# Altium schematic sheet CSI2-IXORA_Rev01.SchDoc(CSI2-IXORA_Rev01)
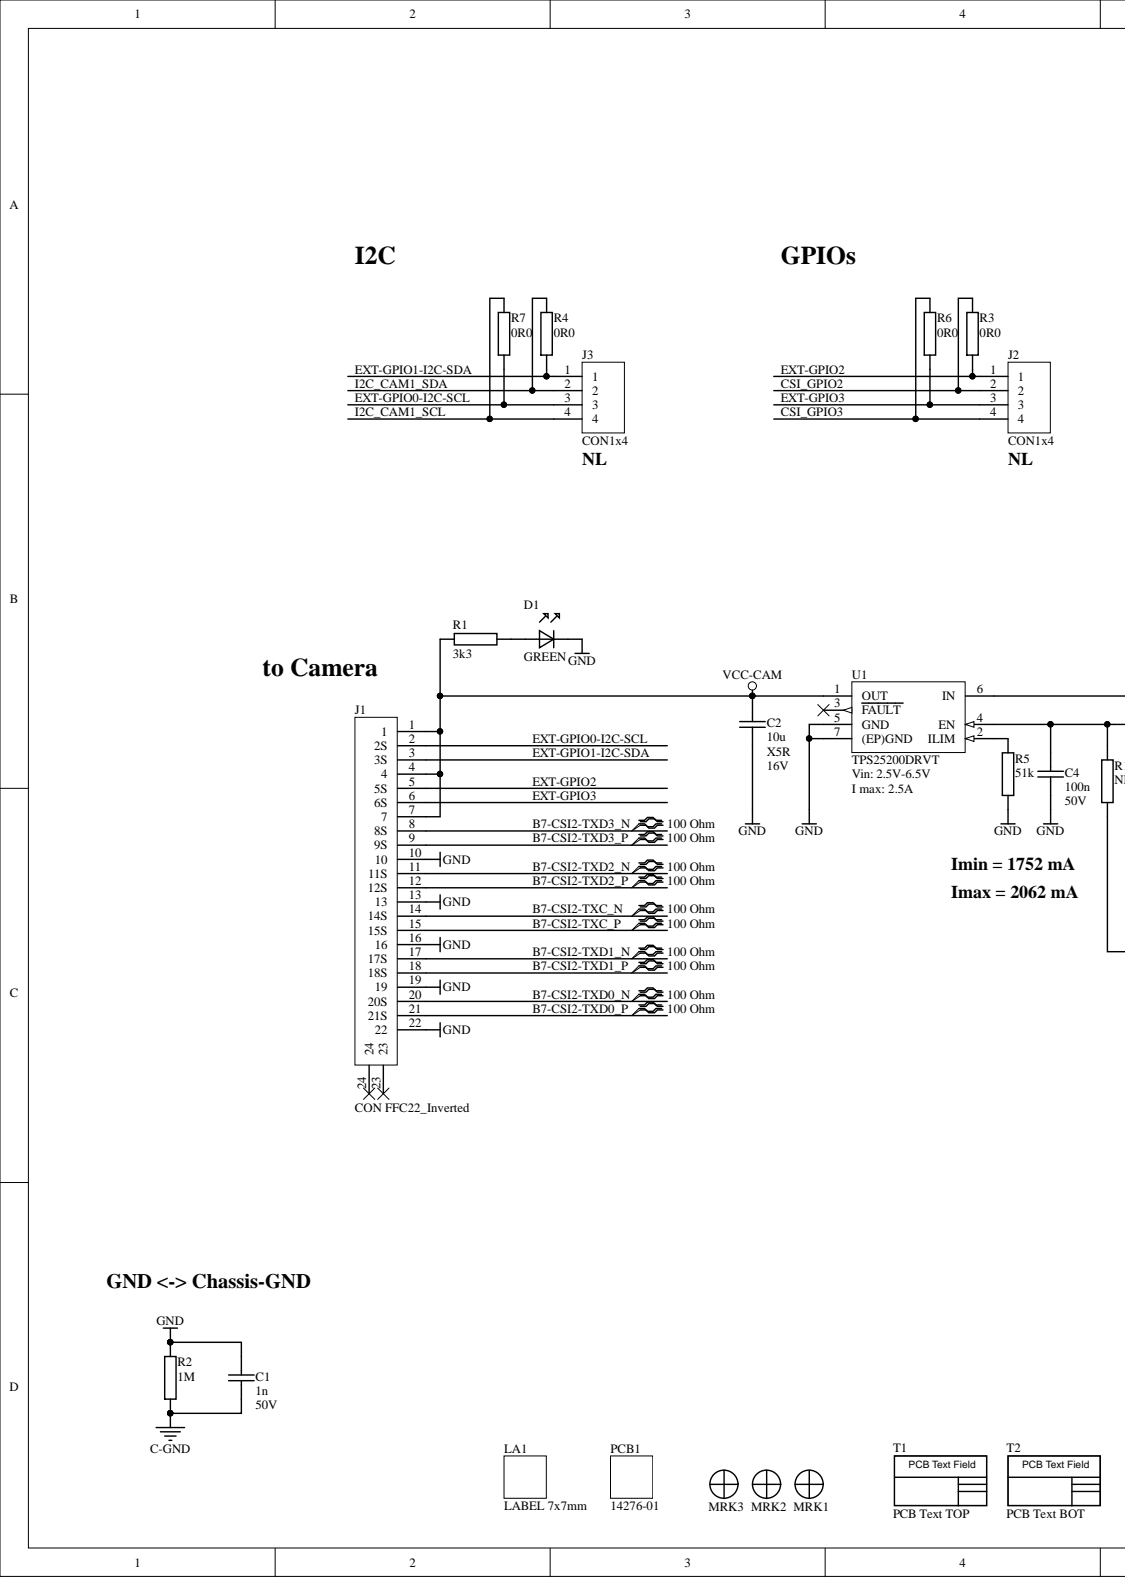
[diagram p0: CSI2-IXORA_Rev01.SchDoc, left]
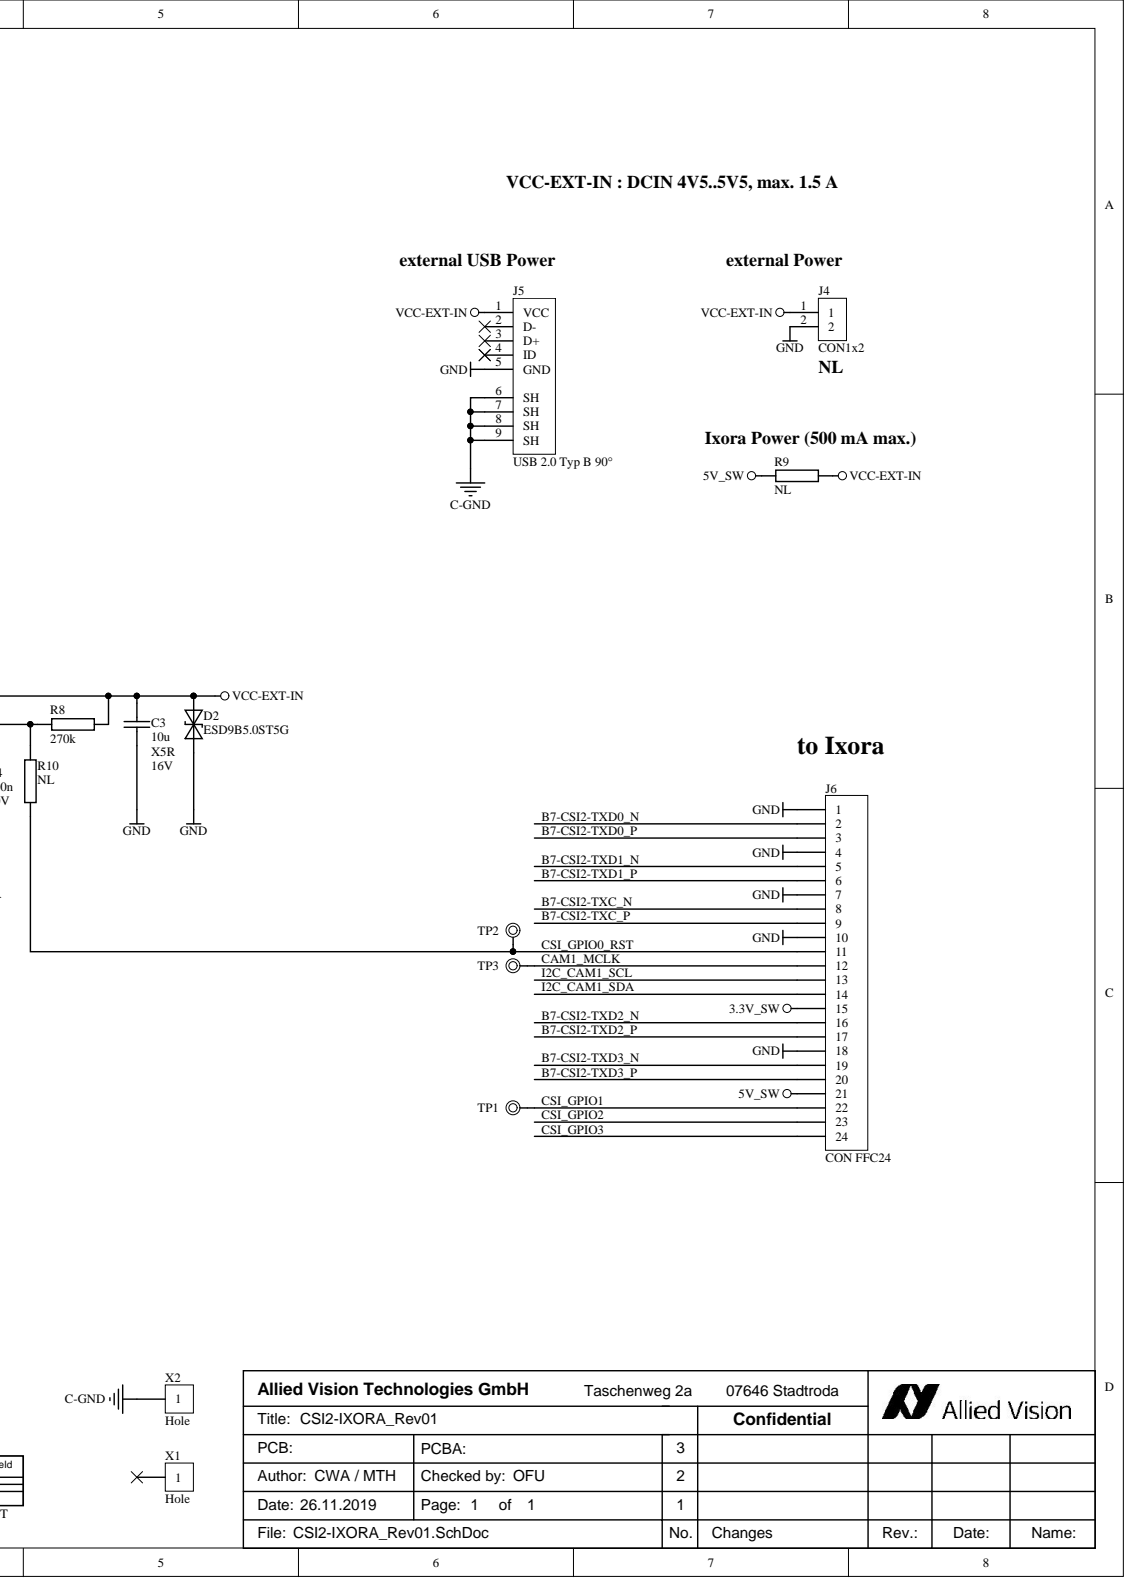
[diagram p1: CSI2-IXORA_Rev01.SchDoc, right]

## Parts
C1  1n  Voltage: 50V  [C-0603_1.0]
C2  10u  Voltage: 16V  Dielectric: X5R  [C-0805_1.4]
C3  10u  Voltage: 16V  Dielectric: X5R  [C-0805_1.4]
C4  100n  Voltage: 50V  [C-0603_1.0]
D1  GREEN  [D-0603-LED]
D2  ESD9B5.0ST5G  [D-SOD923]
J1  CON FFC22_Inverted  [J-FH55-22S-0.5SH - Inverted Pins]
J2  CON1x4  [CON 1x4 RM2.54]
J3  CON1x4  [CON 1x4 RM2.54]
J4  CON1x2  [CON 1x2 RM2.54]
J5  USB 2.0 Typ B 90°  [J-629105150521]
J6  CON FFC24  [J-687124182122]
LA1  LABEL 7x7mm  [PCB LABEL 7x7]
MRK1  Marker Single Layer  [PCB_Marker_Pad_top]  (value not drawn)
MRK2  Marker Single Layer  [PCB_Marker_Pad_top]  (value not drawn)
MRK3  Marker Single Layer  [PCB_Marker_Pad_top]  (value not drawn)
PCB1  14276-01  (mechanical)
R1  3k3  [R-0603_0.6]
R2  1M  [R-0603_0.6]
R3  0R0  [R-0603_0.6]
R4  0R0  [R-0603_0.6]
R5  51k  [R-0603_0.6]
R6  0R0  [R-0603_0.6]
R7  0R0  [R-0603_0.6]
R8  270k  [R-0603_0.6]
R9  NL  [R-0603_0.6]
R10  NL  [R-0603_0.6]
T1  PCB Text TOP  [PCB TEXTFIELD TOP 2]  (no BOM)
T2  PCB Text BOT  [PCB TEXTFIELD BOT 2]  (no BOM)
TP1  TP  [TP_16_10]  (no BOM)
TP2  TP  [TP_16_10]  (no BOM)
TP3  TP  [TP_16_10]  (no BOM)
U1  TPS25200DRVT  Vin: 2.5V-6.5V  I max: 2.5A  [IC-DFN06_2.0x2.0x0.8]
X1  Hole  [HOLE-2.6-5.0-DK]  (no BOM)
X2  Hole  [HOLE-2.6-5.0-DK]  (no BOM)

## Nets
3.3V_SW: J6.15
5V_SW: J6.21 R9.2
C-GND: C1.2 J5.6(SH) J5.7(SH) J5.8(SH) J5.9(SH) R2.1 X2.1
GND: C1.1 C2.2 C3.2 C4.2 D1.K D2.A J1.10 J1.13 J1.16 J1.19 J1.22 J4.2 J5.5(GND) J6.1 J6.4 J6.7 J6.10 J6.18 R2.2 R5.1 U1.5(GND) U1.7((EP)GND)
VCC-CAM: C2.1 J1.1 J1.4 J1.7 R1.1 U1.1(OUT)
VCC-EXT-IN: C3.1 D2.K J4.1 J5.1(VCC) R8.2 R9.1 U1.6(IN)
B7-CSI2-TXC_N: J1.14(14S) J6.8
B7-CSI2-TXC_P: J1.15(15S) J6.9
B7-CSI2-TXD0_N: J1.20(20S) J6.2
B7-CSI2-TXD0_P: J1.21(21S) J6.3
B7-CSI2-TXD1_N: J1.17(17S) J6.5
B7-CSI2-TXD1_P: J1.18(18S) J6.6
B7-CSI2-TXD2_N: J1.11(11S) J6.16
B7-CSI2-TXD2_P: J1.12(12S) J6.17
B7-CSI2-TXD3_N: J1.8(8S) J6.19
B7-CSI2-TXD3_P: J1.9(9S) J6.20
CAM1_MCLK: J6.12 TP3.1
CSI_GPIO0_RST: J6.11 R10.1 TP2.1
CSI_GPIO1: J6.22 TP1.1
CSI_GPIO2: J2.2 J6.23 R3.2
CSI_GPIO3: J2.4 J6.24 R6.2
EXT-GPIO0-I2C-SCL: J1.2(2S) J3.3 R7.1
EXT-GPIO1-I2C-SDA: J1.3(3S) J3.1 R4.1
EXT-GPIO2: J1.5(5S) J2.1 R3.1
EXT-GPIO3: J1.6(6S) J2.3 R6.1
I2C_CAM1_SCL: J3.4 J6.13 R7.2
I2C_CAM1_SDA: J3.2 J6.14 R4.2
NetC4_1: C4.1 R8.1 R10.2 U1.4(EN)
NetD1_A: D1.A R1.2
NetR5_2: R5.2 U1.2(ILIM)

Not connected: J1 23 24; J5 2(D-) 3(D+) 4(ID); U1 3(FAULT); X1 1

## Library data of the parts
C1 = C 1N 50V X7R 0603: Capacitor Ceramic SMD/0603/50V/X7R/10%; Manufacturer Part Number: several; KHK Part Number: K8340038; Tolerance: 10%; Dielectric: X7R; Manufacturer: several; Component Kind: Standard; Library Name: AVT_KHK_Lib.IntLib
C2 C3 = C 10U 16V X5R 0805: Capacitor Ceramic SMD/0805/16V/X5R/10%; Manufacturer Part Number: several; KHK Part Number: K8340151; Tolerance: 10%; Manufacturer: several; Component Kind: Standard; Library Name: AVT_KHK_Lib.IntLib
C4 = C 100N 50V X7R 0603: Capacitor Ceramic SMD/0603/50V/X7R/10%; Manufacturer Part Number: several; KHK Part Number: K8340023; Tolerance: 10%; Dielectric: X7R; Manufacturer: several; Component Kind: Standard; Library Name: AVT_KHK_Lib.IntLib
D1 = D LED 0603 green: Chip LED green 430mcd; Manufacturer Part Number: 150060GS75000; Manufacturer: Würth; KHK Part Number: 8172; Current: 20mA; Component Kind: Standard; Color: green; Library Name: AVT_KHK_Lib.IntLib
D2 = D ESD9B5.0ST5G: DIODE TVS; 400W; 5.8-7.8V; BIDIRECT; Manufacturer: ON Semiconductor; KHK Part Number: 7250; Manufacturer Part Number: ESD9B5.0ST5G; Component Kind: Standard; Library Name: AVT_KHK_Lib.IntLib
J1 = CON FFC22_FH55-22S-0.5SH_Inverted: FFC Connector 0.5mm shielded; Manufacturer: Hirose; KHK Part Number: 10357; Manufacturer Part Number: FH55-22S-0.5SH; Component Kind: Standard; Library Name: AVT_KHK_Lib.IntLib
J2 J3 = CON 1x4 2.54: Stiftleiste; 1x4; 2.54mm; gerade; Manufacturer Part Number: M20-999 0445; Manufacturer: Harwin; KHK Part Number: K7600564; Component Kind: Standard; Library Name: AVT_KHK_Lib.IntLib
J4 = CON 1x2 2.54: Stiftleiste; 1x2; 2.54mm; gerade; Manufacturer Part Number: SL 11 124 2 G; Manufacturer: fischer elektronik; KHK Part Number: K7600582; Component Kind: Standard; Library Name: AVT_KHK_Lib.IntLib
J5 = CON USB 2.0 Typ B 90°: Micro USB 2.0 Typ B 90°; Manufacturer Part Number: 629 105 150 521; Manufacturer: WE; KHK Part Number: 14360; Library Name: Connector.SCHLIB; Component Kind: Standard
J6 = CON FFC24_WE_687124182122: FFC Connector 0.5mm; Manufacturer: Würth; KHK Part Number: 14361; Manufacturer Part Number: 687124182122; Component Kind: Standard; Library Name: AVT_KHK_Lib.IntLib
LA1 = PCB LABEL 7x7: Manufacturer Part Number: several; Manufacturer: several; Description: LABEL 7x7mm; Component Kind: Standard; Library Name: AVT_KHK_Lib.IntLib
MRK1-MRK3 = PCB Marker Pad Single Layer: Position Marker for PCBs; Component Kind: Standard; Library Name: AVT_KHK_Lib.IntLib
PCB1 = PCB: PCB ML04; Manufacturer: several; Manufacturer Part Number: 14276-01; KHK Part Number: 14276-01; Library Name: AVT_KHK_Lib.IntLib
R1 = R 3K3 1% 0603: Standard Resistor SMD/0603/1%/100mW/TK100; Manufacturer Part Number: several; KHK Part Number: K8250070; Tolerance: 1%; Manufacturer: several; Component Kind: Standard; Library Name: AVT_KHK_Lib.IntLib
R2 = R 1MEG 1% 0603: Standard Resistor SMD/0603/1%/100mW/TK100; Manufacturer Part Number: several; KHK Part Number: K8250058; Tolerance: 1%; Manufacturer: several; Component Kind: Standard; Library Name: AVT_KHK_Lib.IntLib
R3 R6 = R 0R0 1% 0603: Standard Resistor SMD/0603/1%/100mW/TK200; Manufacturer Part Number: several; KHK Part Number: K8250041; Tolerance: 1%; Manufacturer: several; Component Kind: Standard; Library Name: AVT_KHK_Lib.IntLib
R4 R7 = R 0R0 1% 0603: Standard Resistor SMD/0603/1%/100mW/TK100; Manufacturer Part Number: several; KHK Part Number: K8250041; Tolerance: 1%; Manufacturer: several; Component Kind: Standard; Library Name: AVT_KHK_Lib.IntLib
R5 = R 51K 1% 0603: Standard Resistor SMD/0603/1%/100mW/TK100; Manufacturer Part Number: several; KHK Part Number: K8250156; Tolerance: 1%; Manufacturer: several; Component Kind: Standard; Library Name: AVT_KHK_Lib.IntLib
R8 = R 270K 1% 0603: Standard Resistor SMD/0603/1%/100mW/TK100; Manufacturer Part Number: several; KHK Part Number: K8250170; Tolerance: 1%; Temperature Coefficient: na; Manufacturer: several; Component Kind: Standard; Library Name: AVT_KHK_Lib.IntLib
R9 R10 = R X 0603: Standard Resistor SMD/0603/1%/100mW/TK200; Tolerance: 1%; Temperature Coefficient: TK100; Component Kind: Standard; Library Name: AVT_KHK_Lib.IntLib
T1 = PCB TEXT FIELD TOP: PCB Textfield TOP; Library Name: AVT_KHK_Lib.IntLib
T2 = PCB TEXT FIELD BOT: PCB Textfield BOT; Library Name: AVT_KHK_Lib.IntLib
TP1-TP3 = TP_16_10: Testpoint: Pad=1,6mm; Hole=1,0mm; Library Name: AVT_KHK_Lib.IntLib
U1 = IC  TPS25200DRVT: IC/PWR/LOADSW;2V5-6V5;2A5;0R099/6-WDFN/2x2x0.8mm; Manufacturer: Texas Instruments; KHK Part Number: 14277; Manufacturer Part Number: TPS25200DRVT; Component Kind: Standard; Library Name: AVT_KHK_Lib.IntLib
X1 X2 = PCB HOLE M2.5-5-DK: HOLE M2.5; Library Name: AVT_KHK_Lib.IntLib
